# Altium schematic sheet Connectors.SchDoc(ADCS_Connectors)
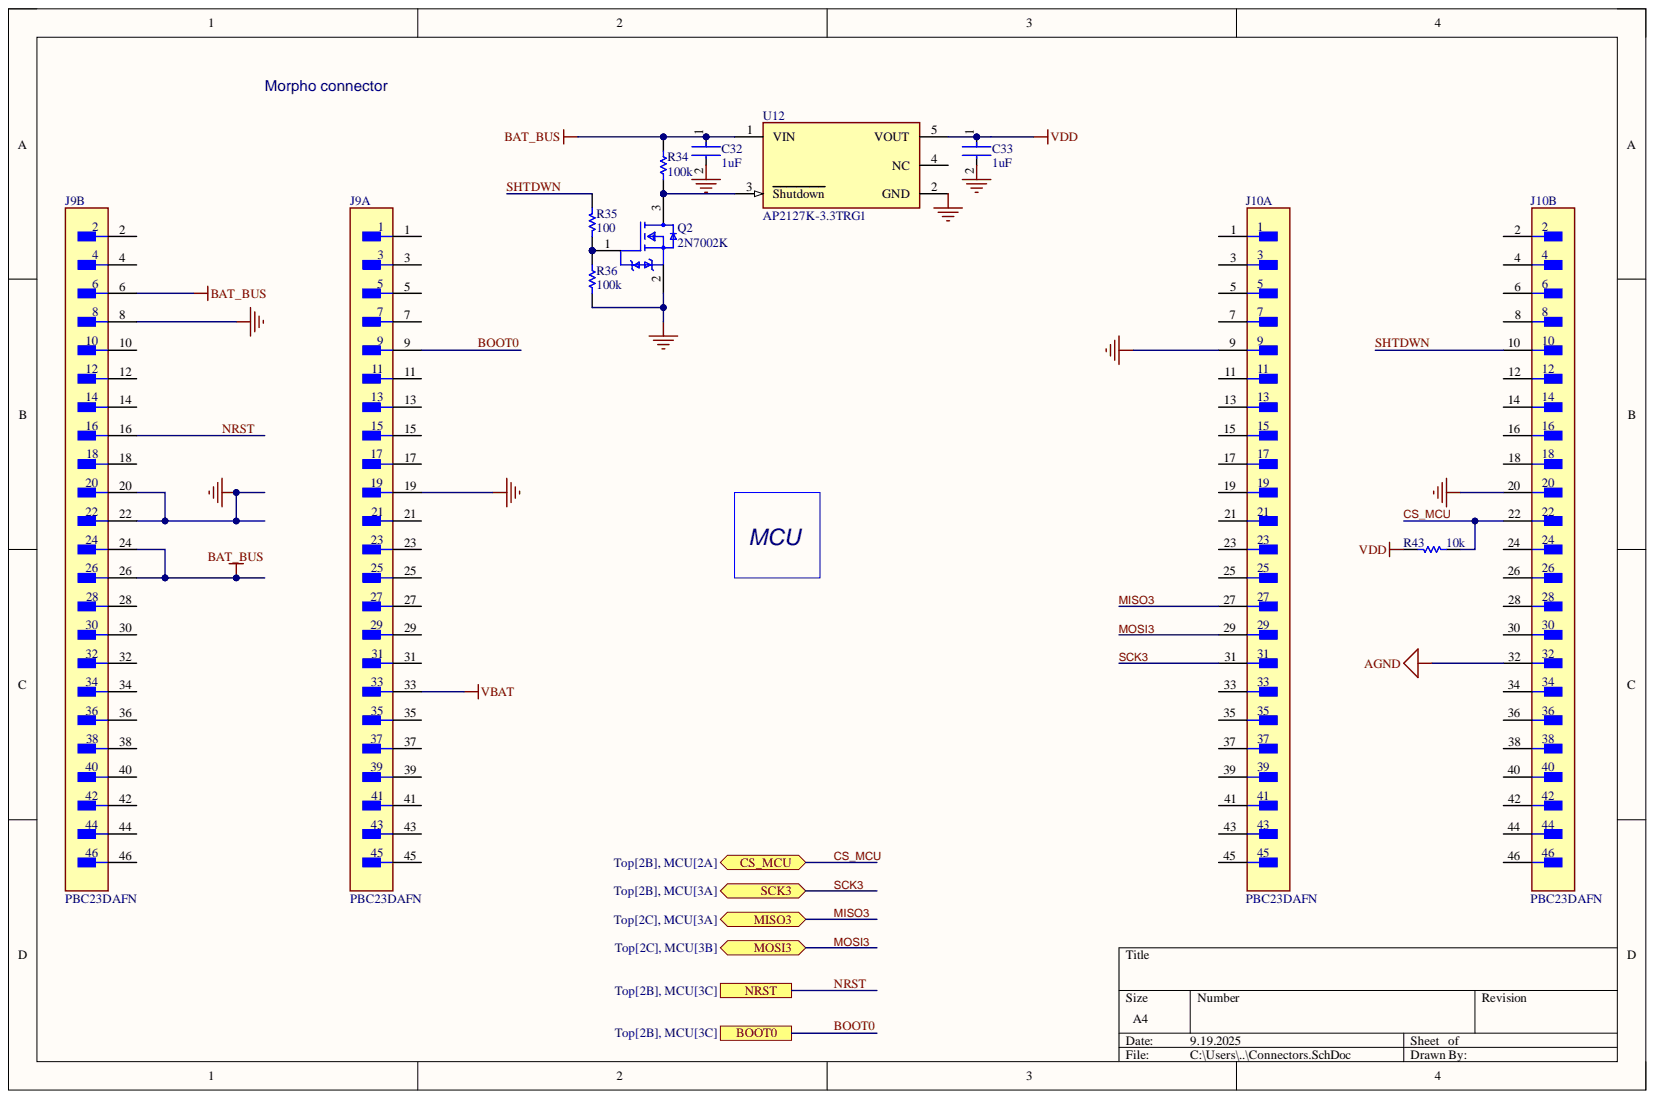
[diagram p0: Connectors.SchDoc]

## Parts
C32  1uF  [FP-0603-L_1_6_0_15-W_0_81-IPC_B]
C33  1uF  [FP-0603-L_1_6_0_15-W_0_81-IPC_B]
J9  PBC23DAFN  [FP-PBC23DAFN-MFG]  (parts A,B)
J10  PBC23DAFN  [FP-PBC23DAFN-MFG]  (parts A,B)
Q2  2N7002K  [FP-318-08-MFG]
R34  100k  [RESC1005X40X25NL05T10]
R35  100  [RESC1608X55X30LL15T15]
R36  100k  [RESC1005X40X25NL05T10]
R43  10k  [RESC1005X40X25LL05T05]
U12  AP2127K-3.3TRG1  [FP-SOT25-MFG]

## Ports
BOOT0, CS_MCU, MISO3, MOSI3, NRST, SCK3

## Nets
AGND: J10.32
BAT_BUS: C32.1 J9.6 J9.24 J9.26 R34.2 U12.1(VIN)
GND: C32.2 C33.2 J9.8 J9.19 J9.20 J9.22 J10.9 J10.20 Q2.2 R36.1 U12.2(GND)
VBAT: J9.33
VDD: C33.1 R43.2 U12.5(VOUT)
BOOT0: J9.9 ; port BOOT0
CS_MCU: J10.22 R43.1 ; port CS_MCU
MISO3: J10.27 ; port MISO3
MOSI3: J10.29 ; port MOSI3
NRST: J9.16 ; port NRST
SCK3: J10.31 ; port SCK3
SHTDWN: J10.10 R35.2
NetJ9_1: J9.1
NetJ9_2: J9.2
NetJ9_3: J9.3
NetJ9_4: J9.4
NetJ9_5: J9.5
NetJ9_7: J9.7
NetJ9_10: J9.10
NetJ9_11: J9.11
NetJ9_12: J9.12
NetJ9_13: J9.13
NetJ9_14: J9.14
NetJ9_15: J9.15
NetJ9_17: J9.17
NetJ9_18: J9.18
NetJ9_21: J9.21
NetJ9_23: J9.23
NetJ9_25: J9.25
NetJ9_27: J9.27
NetJ9_28: J9.28
NetJ9_29: J9.29
NetJ9_30: J9.30
NetJ9_31: J9.31
NetJ9_32: J9.32
NetJ9_34: J9.34
NetJ9_35: J9.35
NetJ9_36: J9.36
NetJ9_37: J9.37
NetJ9_38: J9.38
NetJ9_39: J9.39
NetJ9_40: J9.40
NetJ9_41: J9.41
NetJ9_42: J9.42
NetJ9_43: J9.43
NetJ9_44: J9.44
NetJ9_45: J9.45
NetJ9_46: J9.46
NetJ10_1: J10.1
NetJ10_2: J10.2
NetJ10_3: J10.3
NetJ10_4: J10.4
NetJ10_5: J10.5
NetJ10_6: J10.6
NetJ10_7: J10.7
NetJ10_8: J10.8
NetJ10_11: J10.11
NetJ10_12: J10.12
NetJ10_13: J10.13
NetJ10_14: J10.14
NetJ10_15: J10.15
NetJ10_16: J10.16
NetJ10_17: J10.17
NetJ10_18: J10.18
NetJ10_19: J10.19
NetJ10_21: J10.21
NetJ10_23: J10.23
NetJ10_24: J10.24
NetJ10_25: J10.25
NetJ10_26: J10.26
NetJ10_28: J10.28
NetJ10_30: J10.30
NetJ10_33: J10.33
NetJ10_34: J10.34
NetJ10_35: J10.35
NetJ10_36: J10.36
NetJ10_37: J10.37
NetJ10_38: J10.38
NetJ10_39: J10.39
NetJ10_40: J10.40
NetJ10_41: J10.41
NetJ10_42: J10.42
NetJ10_43: J10.43
NetJ10_44: J10.44
NetJ10_45: J10.45
NetJ10_46: J10.46
NetQ2_1: Q2.1 R35.1 R36.2
NetQ2_3: Q2.3 R34.1 U12.3(Shutdown)
NetU12_4: U12.4(NC)

## Library data of the parts
C32 C33 = CMP-2006-04532-2: General Purpose Ceramic Capacitor, 0603, 1uF, 10%, X5R, 15%, 16V; Dissipation Factor: 12.5%; Insulation Resistance: 10GR; Capacitance: 1uF; Case Code (Imperial): 0603; Case Code (Metric): 1608; Case/Package: 0603; Component Kind: Standard; Construction: Flat; Depth: 0.81mm; Dielectric: X5R; Height: 0.9mm; Lead Free: Lead Free; Length: 1.6mm; Library Name: Altium Content Vault; Max Operating Temperature: 85°C; Min Operating Temperature: -55°C; Mount: Surface Mount; Number of Pins: 2; Package Quantity: 1; Packaging: Tape and Reel; Radiation Hardening: No; REACH SVHC: No SVHC; RoHS Compliant: Yes; Series: -; Termination: SMD/SMT; Thickness: 0.035inch; Tolerance: 10%; Voltage: 16V; Voltage Rating: 16V; Voltage Rating (DC): 16V; Width: 0.81mm
J9 J10 = CMP-25680-000057-1: CONN HEADER VERT 46POS 2.54MM; Connector Type: Male Pin; Gender: Male; Number of Contacts: 46; Pitch: 0.1inch; Color: Black; Component Kind: Standard; Contact Material: Bronze; Contact Mating Length: 0.23inch; Contact Plating: Gold; Contact Type: Male Pin; Height: 2.54mm; Housing Color: Black; Housing Material: Plastic; Lead Free: Lead Free; Length: 58.4mm; Library Name: Altium Content Vault; Mount: Through Hole; Number of Positions: 46; Number of Rows: 2; Package Quantity: 1; Packaging: Bulk; RoHS Compliant: Yes; Row Spacing: 0.1inch; Series: -; Termination: Solder; Width: 5.08mm
Q2 = CMP-07173-000009-1: MOSFET N-CH 60V 300MA SOT23; Manufacturer: ON Semiconductor; Continuous Drain Current: 320mA; Depletion Mode: False; Drain To Source Resistance: 1.19Ω; Gate Charge At Vgs: 0.7nC @ 4.5V; Gate To Source Voltage: 20V; Input Capacitace At Vds: 24.5pF @ 20V; Max Forward Diode Voltage: 1.2V; Max Junction Temp: +150°C; Number Of Channels: 1; Power Dissipation: 300mW; Pulse Drain Current: 5A; Rthja Max: 417°C/W; Standoff Height: 0.01mm; Threshold Vgs Max: 2.3V; Threshold Vgs Min: 1V; Transconductance: 0.53S; Turn Off Delay Time: 55.8ns; Turn On Delay Time: 12.2ns; Typ Forward Diode Voltage: 0.8V; Automotive: No; Category: Trans; Component Kind: Standard; ComponentLink2Description: Datasheet; ComponentLink2URL: https://www.onsemi.com/pub/Collateral/2N7002K-D.pdf; Device Class L1: Discrete Semiconductors; Device Class L2: Transistors; Device Class L3: MOSFETs; Digikey Description: MOSFET N-CH 60V 300MA SOT23; Drain To Source Breakdown Voltage: 60V; Drain To Source Voltage: 60V; Footprint Url: https://www.onsemi.com/pub/Collateral/318-08.PDF; Height: 1.11mm; Ipc Land Pattern Name: SOT95P240X100-3; Lead Free: Yes; Library Name: Altium Content Vault; Manufacturer Part Number: 2N7002K; Mouser Description: N-Channel 60 V 2 Ohm SMT Enhancement Mode Field Effect Transistor SOT-23; Number Of N Channels: 1; Octopart: https://octopart.com/2n7002k-on+semiconductor-84408900; Package: 318-08; RoHS: TRUE; Temperature: -55°C to +150°C; Temperature Range High: +150°C; Temperature Range Low: -55°C
R34 R36 = CMP-2002-07343-1: Manufacturer: Yageo / Phycomp; Part Number: RC0402FR-07100KL; Component Kind: Standard; Library Name: Altium Content Vault
R35 = CMP-2000-00179-1: Manufacturer: Panasonic; Part Number: ERJ-3EKF1000V; Component Kind: Standard; Library Name: Altium Content Vault
R43 = CMP-2000-07588-1: Chip Resistor, 10 KOhm, +/- 1%, 0.1 W, -55 to 155 degC, 0402 (1005 Metric), RoHS, Tape and Reel; Manufacturer: Panasonic; Case\/Package: 0402; Max Power Dissipation: 100mW; Resistance: 10KOhm; Temperature Coefficient: 100ppm\/degreesC; Voltage Rating: 50V; Case Code (Imperial): 0402; Case Code (Metric): 1005; Component Kind: Standard; Composition: ThickFilm; Contact Plating: Tin; Datasheet URL: https://industrial.panasonic.com/cdbs/www-data/pdf/RDA0000/AOA0000C304.pdf; Datasheet Version: 02/2017; Depth: 0.5mm; Height: 0.35mm; Lead Free: LeadFree; Length: 1mm; Library Name: Altium Content Vault; Manufacturer URL: http://www.panasonic.com/; Max Operating Temperature: 155degC; Military Standard: Not; Min Operating Temperature: -55degC; Mount: SurfaceMount; Mounting Technology: SurfaceMount; Number of Pins: 2; Number of Terminations: 2; Package Description: 2-Pin Surface Mount Device, Body 1 x 0.5 mm; Package Quantity: 1; Package Reference: 402; Packaging: TapeandReel; Power Rating: 100mW; Radiation Hardening: No; REACH SVHC: NoSVHC; RoHSCompliant: True; Termination: SMD/SMT; Tolerance: 1%; Voltage Rating (DC): 50V; Width: 0.02inch
U12 = CMP-12756-000001-1: IC REG LINEAR 3.3V 300MA SOT23-5; Manufacturer: Diodes Inc.; Accuracy Percentage: 2%; Dropout Voltage: 170mV; Max Junction Temp: +150°C; Max Supply Voltage: 6V; Min Supply Voltage: 2.5V; Nominal Supply Current: 60uA; Number Of Outputs: 1; Output Current: 400mA; Output Type: Fixed; Standoff Height: 0.013mm; Ambient Temperature Range High: +85°C; Ambient Temperature Range Low: -40°C; Automotive: No; Category: IC; Component Kind: Standard; ComponentLink2Description: Datasheet; ComponentLink2URL: https://www.diodes.com/assets/Datasheets/AP2127.pdf; Device Class L1: Integrated Circuits (ICs); Device Class L2: Power Management ICs; Device Class L3: Voltage Regulators - Linear; Digikey Description: IC REG LINEAR 3.3V 300MA SOT23-5; Footprint Url: https://www.diodes.com/assets/Package-Files/SOT25.pdf; Height: 1.4mm; Lead Free: Yes; Library Name: Altium Content Vault; Manufacturer Part Number: AP2127K-3.3TRG1; Mouser Description: LDO Voltage Regulators 300mA CMOS LDO 2.5V to 6V; Octopart: https://octopart.com/ap2127k-3.3trg1-diodes+inc.-30954597; Output Voltage: 3.3V; Package: SOT25; RoHS: TRUE; Temperature: -40°C to +85°C; Temperature Range High: +85°C; Temperature Range Low: -40°C
